# Altium schematic sheet MCU.SchDoc
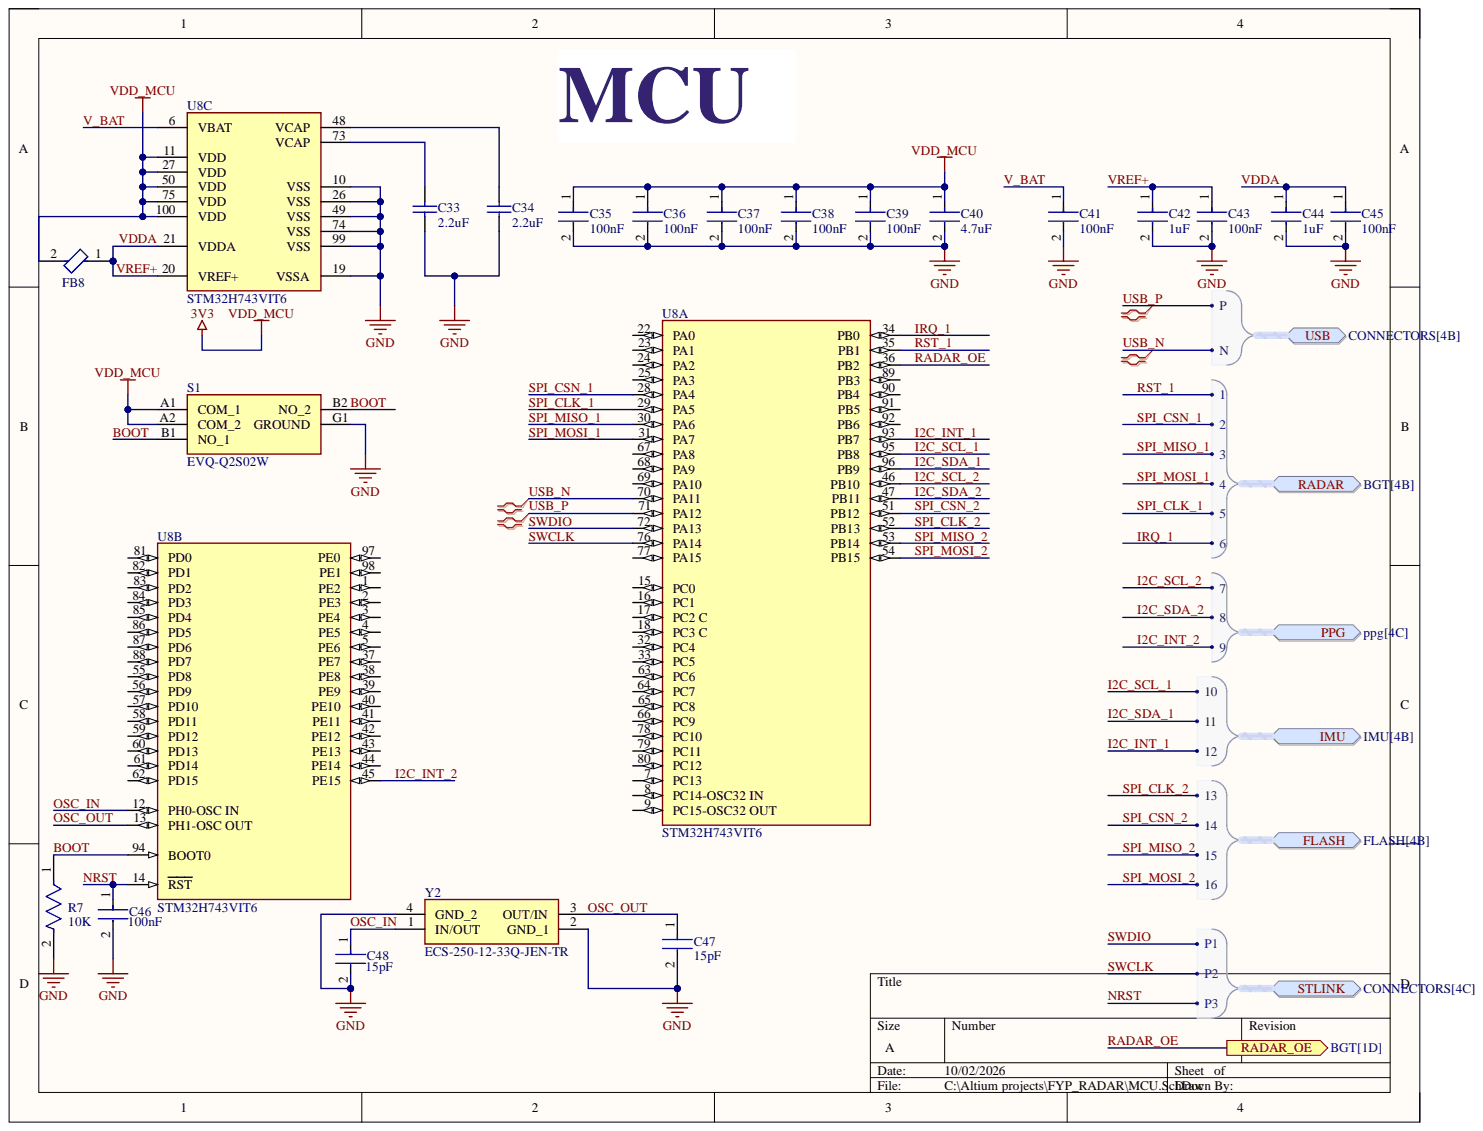
[diagram p0: MCU.SchDoc]

## Parts
C33  2.2uF  [CAPC2013X140X50LL20T25]
C34  2.2uF  [CAPC2013X140X50LL20T25]
C35  100nF  [FP-C0805C-DN-MFG]
C36  100nF  [FP-C0805C-DN-MFG]
C37  100nF  [FP-C0805C-DN-MFG]
C38  100nF  [FP-C0805C-DN-MFG]
C39  100nF  [FP-C0805C-DN-MFG]
C40  4.7uF  [FP-C0805C475K3PACTU-MFG]
C41  100nF  [FP-C0805C-DN-MFG]
C42  1uF  [FP-CC0805-0_85-MFG]
C43  100nF  [FP-C0805C-DN-MFG]
C44  1uF  [FP-CC0805-0_85-MFG]
C45  100nF  [FP-C0805C-DN-MFG]
C46  100nF  [FP-C0805C-DN-MFG]
C47  15pF  [FP-C0805C-DN-MFG]
C48  15pF  [FP-C0805C-DN-MFG]
FB8  MMZ1005B601CT000  [FP-MMZ1005-IPC_A]  (value not drawn)
R7  10K  [FP-CRGCQ0805-IPC_C]
S1  EVQ-Q2S02W  [EVQQ2S02W]
U8  STM32H743VIT6  [FP-LQFP100-1L-MFG]  (parts A,B,C)
Y2  ECS-250-12-33Q-JEN-TR  [ECS2401233QJESTR]

## Ports
FLASH, FLASH.13, FLASH.14, FLASH.15, FLASH.16, IMU, IMU.10, IMU.11, IMU.12, PPG, PPG.7, PPG.8, PPG.9, RADAR, RADAR.1, RADAR.2, RADAR.3, RADAR.4, RADAR.5, RADAR.6, RADAR_OE, STLINK, STLINK.P1, STLINK.P2, STLINK.P3, USB, USB.N, USB.P

## Nets
3V3: C35.1 C36.1 C37.1 C38.1 C39.1 C40.1 FB8.2 S1.A1(COM_1) S1.A2(COM_2) U8.11(VDD) U8.27(VDD) U8.50(VDD) U8.75(VDD) U8.100(VDD)
GND: C33.1 C34.1 C35.2 C36.2 C37.2 C38.2 C39.2 C40.2 C41.2 C42.2 C43.2 C44.2 C45.2 C46.2 C47.2 C48.2 R7.2 S1.G1(GROUND) U8.10(VSS) U8.19(VSSA) U8.26(VSS) U8.49(VSS) U8.74(VSS) U8.99(VSS) Y2.2(GND_1) Y2.4(GND_2)
BOOT: R7.1 S1.B1(NO_1) S1.B2(NO_2) U8.94(BOOT0)
I2C_INT_1: U8.93(PB7) ; port IMU
I2C_INT_2: U8.45(PE15) ; port PPG
I2C_SCL_1: U8.95(PB8) ; port IMU
I2C_SCL_2: U8.46(PB10) ; port PPG
I2C_SDA_1: U8.96(PB9) ; port IMU
I2C_SDA_2: U8.47(PB11) ; port PPG
IRQ_1: U8.34(PB0) ; port RADAR
NRST: C46.1 U8.14(RST) ; port STLINK
OSC_IN: C48.1 U8.12(PH0-OSC_IN) Y2.1(IN/OUT)
OSC_OUT: C47.1 U8.13(PH1-OSC_OUT) Y2.3(OUT/IN)
RADAR_OE: U8.36(PB2) ; port RADAR_OE
RST_1: U8.35(PB1) ; port RADAR
SPI_CLK_1: U8.29(PA5) ; port RADAR
SPI_CLK_2: U8.52(PB13) ; port FLASH
SPI_CSN_1: U8.28(PA4) ; port RADAR
SPI_CSN_2: U8.51(PB12) ; port FLASH
SPI_MISO_1: U8.30(PA6) ; port RADAR
SPI_MISO_2: U8.53(PB14) ; port FLASH
SPI_MOSI_1: U8.31(PA7) ; port RADAR
SPI_MOSI_2: U8.54(PB15) ; port FLASH
SWCLK: U8.76(PA14) ; port STLINK
SWDIO: U8.72(PA13) ; port STLINK
USB_N: U8.70(PA11) ; port USB
USB_P: U8.71(PA12) ; port USB
V_BAT: C41.1 U8.6(VBAT)
VDDA: C42.1 C43.1 C44.1 C45.1 FB8.1 U8.20(VREF+) U8.21(VDDA)
NetC33_2: C33.2 U8.73(VCAP)
NetC34_2: C34.2 U8.48(VCAP)

Not connected: U8 1(PE2) 2(PE3) 3(PE4) 4(PE5) 5(PE6) 7(PC13) 8(PC14-OSC32_IN) 9(PC15-OSC32_OUT) 15(PC0) 16(PC1) 17(PC2_C) 18(PC3_C) 22(PA0) 23(PA1) 24(PA2) 25(PA3) 32(PC4) 33(PC5) 37(PE7) 38(PE8) 39(PE9) 40(PE10) 41(PE11) 42(PE12) 43(PE13) 44(PE14) 55(PD8) 56(PD9) 57(PD10) 58(PD11) 59(PD12) 60(PD13) 61(PD14) 62(PD15) 63(PC6) 64(PC7) 65(PC8) 66(PC9) 67(PA8) 68(PA9) 69(PA10) 77(PA15) 78(PC10) 79(PC11) 80(PC12) 81(PD0) 82(PD1) 83(PD2) 84(PD3) 85(PD4) 86(PD5) 87(PD6) 88(PD7) 89(PB3) 90(PB4) 91(PB5) 92(PB6) 97(PE0) 98(PE1)

## Library data of the parts
C33 C34 = CMP-2007-03314-1: Manufacturer: Kemet; Part Number: C0805X225K8RACTU; Component Kind: Standard; Library Name: Altium Content Vault
C35-C39 C41 C43 C45 C46 = CMP-2007-00274-2: CAP CER 0.1UF 16V X7R 0805; Manufacturer: KEMET; Manufacturer Part Number: C0805C104K4RACTU; Capacitance: 0.10 μF; Material: Ceramic; Temperature Coefficient: 30ppm/°C; Tolerance: 0.1; Value: 0.10 μF; Automotive: No; Category: Cap; Component Kind: Standard; ComponentLink2Description: Datasheet; ComponentLink2URL: https://content.kemet.com/datasheets/KEM_C1003_C0G_SMD.pdf; Device Class L1: Passive Components; Device Class L2: Capacitors; Device Class L3: Ceramic Capacitors; Digikey Description: CAP CER 0.1UF 16V X7R 0805; Height: 0.88mm; Ipc Land Pattern Name: CAPC200125X78; Lead Free: Yes; Library Name: Altium Content Vault; Mouser Description: Multilayer Ceramic Capacitors MLCC - SMD/SMT 16volts 0.1uF X7R 10%; Octopart: https://octopart.com/c0805c104k4ractu-kemet-92155; Package: C0805C-DN; RoHS: TRUE; Temperature: -55°C to +125°C; Temperature Characteristic: X7R; Temperature Range High: +125°C; Temperature Range Low: -55°C; Voltage Rating: 16 V
C40 = CMP-2007-01010-2: CAP CER 4.7UF 25V X5R 0805; Dielectric Material: Ceramic; Dissipation Factor: 5%; Tolerance: 10%; Capacitance: 4.7uF; Case Code (Imperial): 0805; Case Code (Metric): 2012; Case/Package: 0805; Component Kind: Standard; Construction: Flat; Contact Plating: Tin; Depth: 1.25mm; Dielectric: X5R; Height: 1.25mm; Lead Free: Lead Free; Length: 2mm; Library Name: Altium Content Vault; Max Operating Temperature: 85°C; Min Operating Temperature: -55°C; Mount: PCB; Number of Pins: 2; Package Quantity: 2500; Packaging: Tape and Reel; Radiation Hardening: No; REACH SVHC: No SVHC; RoHS Compliant: Yes; Series: C0805C; Termination: SMD/SMT; Voltage: 25V; Voltage Rating (DC): 25V; Width: 1.2mm
C42 C44 = CMP-03422-000309-1: 0805 1 uF 50 V ±10% Tolerance X5R SMT Multilayer Ceramic Capacitor; Manufacturer: Yageo; Manufacturer Part Number: CC0805KKX5R9BB105; Tolerance: 0.1; Voltage Rating: 50 V; Automotive: No; Capacitance: 1 uF; Category: Cap; Component Kind: Standard; ComponentLink2Description: Datasheet; ComponentLink2URL: http://www.yageo.com/documents/recent/UPY-GPHC_Y5V_6.3V-to-50V_8.pdf; Device Class L1: Passive Components; Device Class L2: Capacitors; Device Class L3: Ceramic Capacitors; Digikey Description: 0805 1 uF 50 V ±10% Tolerance X5R SMT Multilayer Ceramic Capacitor; Footprint Url: http://www.yageo.com/exep/pages/download/literatures/UPY-C_GEN_24.pdf; Height: 0.95mm; Ipc Land Pattern Name: CAPC200125X85; Lead Free: Yes; Library Name: Altium Content Vault; Material: Ceramic; Mouser Description: 0805 1 uF 50 V ±10% Tolerance X5R SMT Multilayer Ceramic Capacitor; Octopart: https://octopart.com/cc0805kkx5r9bb105-yageo-57080769; Package: CC0805-0.85; RoHS: TRUE; Temperature: -30°C to +85°C; Temperature Characteristic: X5R; Temperature Range High: +85°C; Temperature Range Low: -30°C; Value: 1 uF
C47 C48 = CMP-03020-030239-1: C0805 15 pF X8R 30ppm/°C 5.00% 50 V; Manufacturer: KEMET; Manufacturer Part Number: C0805C150J5HACTU; Material: Ceramic; Tolerance: 0.05; Voltage Rating: 50 V; Automotive: No; Capacitance: 15 pF; Category: Cap; Component Kind: Standard; ComponentLink2Description: Datasheet; ComponentLink2URL: https://content.kemet.com/datasheets/KEM_C1003_C0G_SMD.pdf; Device Class L1: Passive Components; Device Class L2: Capacitors; Device Class L3: Ceramic Capacitors; Height: 0.88mm; Ipc Land Pattern Name: CAPC200125X78; Lead Free: Yes; Library Name: Altium Content Vault; Octopart: https://octopart.com/c0805c150j5hactu-kemet-88696716; Package: C0805C-DN; RoHS: TRUE; Temperature: -55°C to +125°C; Temperature Characteristic: X8R; Temperature Coefficient: 30ppm/°C; Temperature Range High: +125°C; Temperature Range Low: -55°C; Value: 15 pF
FB8 = CMP-08257-000327-1: Signal Line Ferrite Bead 600Ω @ 100MHz 200mA DCR 850mΩ SMD 0402; DC Resistance (DCR): 850mR; Impedance: 600R; Tolerance: 25%; Case Code (Imperial): 0402; Case Code (Metric): 1005; Case/Package: 0402; Component Kind: Standard; Core Material: Ferrite; Current Rating: 200mA; Height: 0.022inch; Lead Free: Lead Free; Length: 0.039inch; Library Name: Altium Content Vault; Max DC Current: 200mA; Mount: Surface Mount; Package Quantity: 1; Packaging: Tape and Reel; REACH SVHC: No SVHC; RoHS Compliant: Yes; Width: 0.02inch
R7 = CMP-03211-002989-1: CRGCQ 0805 10K 5%; Manufacturer: TE Connectivity; Manufacturer Part Number: CRGCQ0805J10K; Automotive Grade: Grade 914; Power Rating: 0.125W; Resistance: 10KΩ; Temperature Coefficient: ±100ppm/°C; Tolerance: 0.05; Value: 10KΩ; Voltage Rating: 150V; Ambient Temperature Range High: +70°C; Automotive: Yes; Category: Res; Component Kind: Standard; ComponentLink2Description: Datasheet; ComponentLink2URL: https://www.te.com/commerce/DocumentDelivery/DDEController?Action=showdoc&DocId=Data+Sheet%7F1773204-3%7F1%7Fpdf%7FEnglish%7FENG_DS_1773204-3_1.pdf%7F4-2176341-923; Composition: Thick Film; Device Class L1: Passive Components; Device Class L2: Resistors; Device Class L3: Chip SMD Resistors; Digikey Description: CRGCQ 0805 10K 5%; Height: 0.65mm; Ipc Land Pattern Name: RESC200125X55; Lead Free: Yes; Library Name: Altium Content Vault; Mouser Description: Res Thick Film 0805 10K Ohm 5% 0.125W(1/8W) ±100ppm/C Pad SMD Automotive T/R; Octopart: https://octopart.com/crgcq0805j10k-te+connectivity-91018201; Package: CRGCQ0805; RoHS: TRUE; Series: CRGCQ; Temperature: -55°C to +155°C; Temperature Range High: +155°C; Temperature Range Low: -55°C
S1 = EVQ-Q2S02W: Switch; Manufacturer_Name: Panasonic; Component Kind: Standard; Datasheet Link: https://www3.panasonic.biz/ac/e_download/control/switch/light-touch/catalog/sw_lt_eng_6s_th.pdf?f_cd=402892; Height: 2.7mm; Manufacturer_Part_Number: EVQ-Q2S02W; Mouser Part Number: 667-EVQ-Q2S02W; Mouser Price/Stock: https://www.mouser.co.uk/ProductDetail/Panasonic/EVQ-Q2S02W?qs=WwqriLBepZvEBOQ7RHVqQQ%3D%3D
U8 = CMP-12105-000050-1: IC MCU 32BIT 2MB FLASH 100LQFP; Manufacturer: STMicroelectronics; Core Architecture: Arm Cortex-M7; Data Bus Width: 32bits; Frequency: 480MHz; Interface: I2C,SPI,UART,USART,USB,LIN,IrDA,Ethernet,I2S,Other; Max Junction Temp: +125°C; Max Supply Voltage: 3.6V; Memory Size: 2MBytes; Min Supply Voltage: 1.71V; Number Of A/D Converters: 3; Number Of Adc Channels: 36; Number Of Cores: 1; Number Of I2C Channels: 4; Number Of I/Os: 82; Number Of Pwm Channels: 42; Number Of Spi Channels: 6; Number Of Timers/Counters: 20; Number Of Uart Channels: 9; Number Of Usb Channels: 2; Ram Size: 1060KBytes; Standoff Height: 0.05mm; Ambient Temperature Range High: +85°C; Ambient Temperature Range Low: -40°C; Automotive: No; Category: IC; Component Kind: Standard; ComponentLink2Description: Datasheet; ComponentLink2URL: https://www.st.com/resource/en/datasheet/stm32h743vi.pdf; Device Class L1: Integrated Circuits (ICs); Device Class L2: Embedded Processors and Controllers; Device Class L3: Microcontrollers; Digikey Description: IC MCU 32BIT 2MB FLASH 100LQFP; Height: 1.6mm; Ipc Land Pattern Name: QFP50P1600X1600X160-100; Lead Free: Yes; Library Name: Altium Content Vault; Manufacturer Part Number: STM32H743VIT6; Memory Type: FLASH; Number Of Ethernet Channels: 1; Octopart: https://octopart.com/stm32h743vit6-stmicroelectronics-76372527; Package: LQFP100-1L; RoHS: TRUE; Temperature: -40°C to +125°C; Temperature Range High: +125°C; Temperature Range Low: -40°C
Y2 = ECS-250-12-33Q-JEN-TR: Crystal or Oscillator; Manufacturer_Name: ECS; Arrow Part Number: ECS-250-12-33Q-JEN-TR; Arrow Price/Stock: https://www.arrow.com/en/products/ecs-250-12-33q-jen-tr/ecs-international?region=nac; Component Kind: Standard; Datasheet Link: https://ecsxtal.com/store/pdf/ECX-33Q.pdf; Height: 0mm; Manufacturer_Part_Number: ECS-250-12-33Q-JEN-TR; Mouser Part Number: 520-250-12-33QJENT; Mouser Price/Stock: https://www.mouser.co.uk/ProductDetail/ECS/ECS-250-12-33Q-JEN-TR?qs=w%2Fv1CP2dgqpMp3lp1L5S4Q%3D%3D
